# Altium schematic sheet Microcontroller.SchDoc(Microcontroller)
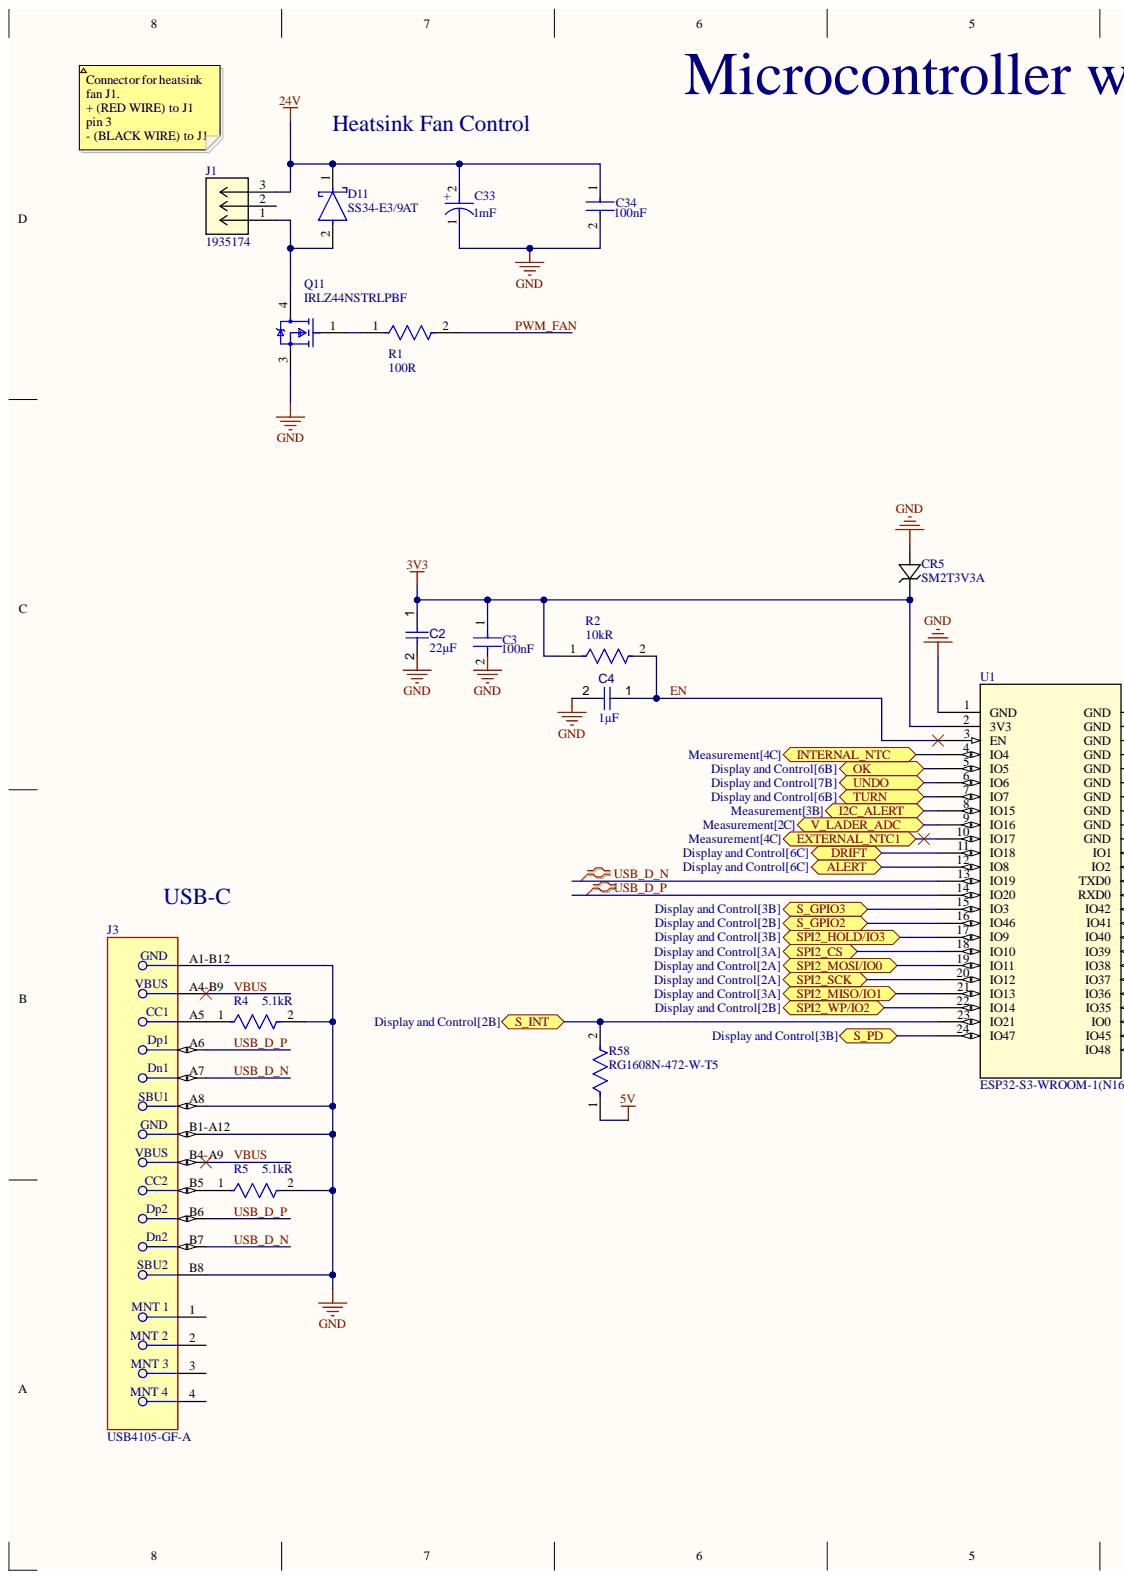
[diagram p0: Microcontroller.SchDoc, left]
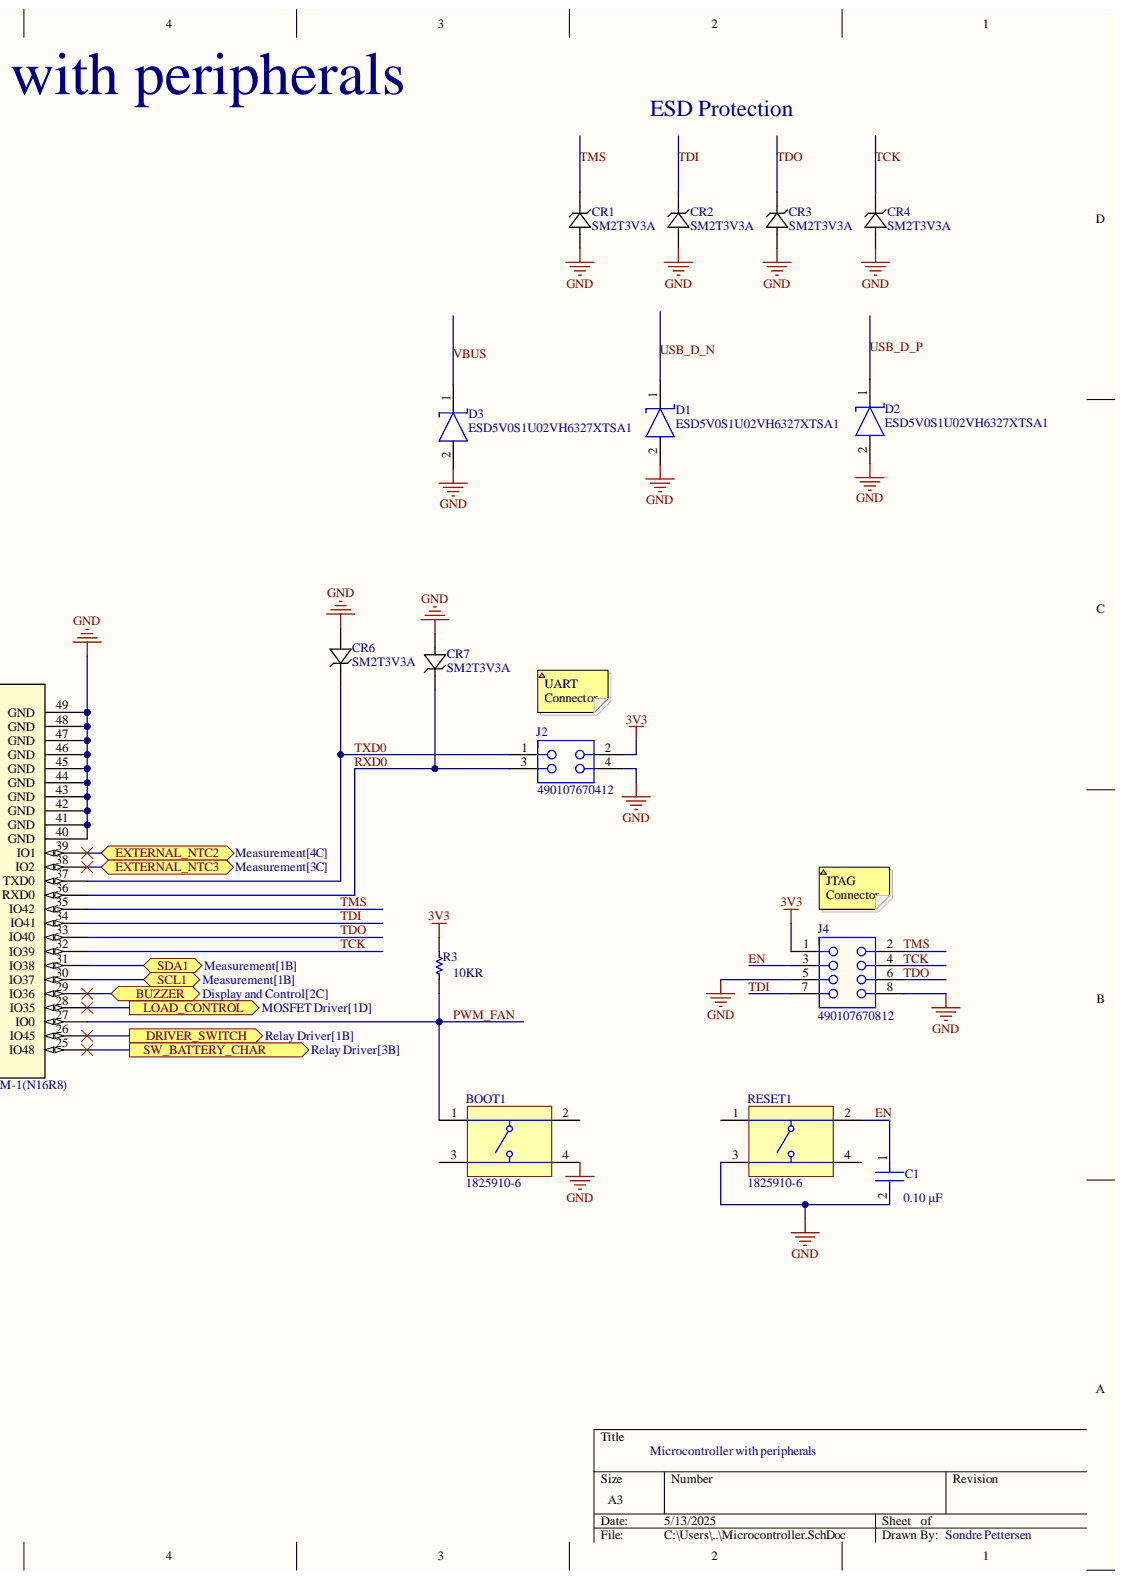
[diagram p1: Microcontroller.SchDoc, right]

## Parts
BOOT1  1825910-6  [TECO-1825910-6_V]
C1  value 0.10 μF  Capacitance: 0.10 μF  [FP-C0402-BB-MFG]  (hidden comment: C0402C104K4RACTU)
C2  value 22µF  [CAPC0805(2012)145_L]  (hidden comment: Capacitor 22µF +/-40% 16V 0805)
C3  Capacitance: 100nF  [FP-0603-L_1_6_0_15-W_0_81-IPC_C]  (hidden comment: 06035C104K4T2A)
C4  value 1µF  [CAPC1608X90X35ML15T15]  (hidden comment: Capacitor 1uF +/- 10% 16V 0603)
C33  Capacitance: 1mF  [FP-RAD-TH-D_12_5_0_5-L_25-MFG]  (hidden comment: ECA1HM102)
C34  Capacitance: 100nF  [FP-CL31-IPC_C]  (hidden comment: CL31B104KBCNFNC)
CR1  SM2T3V3A  [CR_DO-216AA_STMITE_STM]
CR2  SM2T3V3A  [CR_DO-216AA_STMITE_STM]
CR3  SM2T3V3A  [CR_DO-216AA_STMITE_STM]
CR4  SM2T3V3A  [CR_DO-216AA_STMITE_STM]
CR5  SM2T3V3A  [CR_DO-216AA_STMITE_STM]
CR6  SM2T3V3A  [CR_DO-216AA_STMITE_STM]
CR7  SM2T3V3A  [CR_DO-216AA_STMITE_STM]
D1  ESD5V0S1U02VH6327XTSA1  [FP-PG-SC79-2-MFG]
D2  ESD5V0S1U02VH6327XTSA1  [FP-PG-SC79-2-MFG]
D3  ESD5V0S1U02VH6327XTSA1  [FP-PG-SC79-2-MFG]
D11  SS34-E3/9AT  [FP-SMC-MFG]
J1  1935174  [CONN_1935174_PXC]
J2  490107670412  [490107670412_Permanent Application]
J3  USB4105-GF-A  [FP-USB4105-GF-A-MFG]
J4  490107670812  [490107670812_Permanent Application]
Q11  IRLZ44NSTRLPBF  [FP-PG-TO263-3-MFG]
R1  Resistance: 100R  [FP-ERA3A-IPC_B]  (hidden comment: ERA-3AEB101V)
R2  value 10kR  [FP-CPF0603-MFG]  (hidden comment: CPF0603B10KE1)
R3  value 10KR  [RESC2013X60X35NL10T20]  (hidden comment: RC0805FR-0710KL)
R4  Resistance: 5.1kR  [FP-CRCW0603-e3-IPC_C]  (hidden comment: CRCW06035K10FKEA)
R5  Resistance: 5.1kR  [FP-CRCW0603-e3-IPC_C]  (hidden comment: CRCW06035K10FKEA)
R58  RG1608N-472-W-T5  [FP-RG1608-MFG]
RESET1  1825910-6  [TECO-1825910-6_V]
U1  ESP32-S3-WROOM-1(N16R8)  [ESP32-S3-WROOM-1_EXP]

## Ports
ALERT, BUZZER, DRIFT, DRIVER_SWITCH, EXTERNAL_NTC1, EXTERNAL_NTC2, EXTERNAL_NTC3, I2C_ALERT, INTERNAL_NTC, LOAD_CONTROL, OK, S_GPIO2, S_GPIO3, S_INT, S_PD, SCL1, SDA1, SPI2_CS, SPI2_HOLD/IO3, SPI2_MISO/IO1, SPI2_MOSI/IO0, SPI2_SCK, SPI2_WP/IO2, SW_BATTERY_CHAR, TURN, UNDO, V_LADER_ADC

## Nets
3V3: C2.1 C3.1 CR5.1 J2.2 J4.1 R2.1 R3.2 U1.2(3V3)
5V: R58.1
24V: C33.2 C34.1 D11.1 J1.3
GND: BOOT1.4 C1.2 C2.2 C3.2 C4.2 C33.1 C34.2 CR1.2 CR2.2 CR3.2 CR4.2 CR5.2 CR6.2 CR7.2 D1.2 D2.2 D3.2 J2.4 J3.A1-B12 J3.A8 J3.B1-A12 J3.B8 J4.5 J4.8 Q11.3 R4.2 R5.2 RESET1.3 U1.1(GND) U1.40(GND) U1.41(GND) U1.42(GND) U1.43(GND) U1.44(GND) U1.45(GND) U1.46(GND) U1.47(GND) U1.48(GND) U1.49(GND)
ALERT: U1.12(IO8) ; port ALERT
BUZZER: U1.29(IO36) ; port BUZZER
DRIFT: U1.11(IO18) ; port DRIFT
DRIVER_SWITCH: U1.26(IO45) ; port DRIVER_SWITCH
EN: C1.1 C4.1 J4.3 R2.2 RESET1.2 U1.3(EN)
EXTERNAL_NTC1: U1.10(IO17) ; port EXTERNAL_NTC1
EXTERNAL_NTC2: U1.39(IO1) ; port EXTERNAL_NTC2
EXTERNAL_NTC3: U1.38(IO2) ; port EXTERNAL_NTC3
I2C_ALERT: U1.8(IO15) ; port I2C_ALERT
INTERNAL_NTC: U1.4(IO4) ; port INTERNAL_NTC
LOAD_CONTROL: U1.28(IO35) ; port LOAD_CONTROL
OK: U1.5(IO5) ; port OK
PWM_FAN: BOOT1.1 R1.2 R3.1 U1.27(IO0)
RXD0: CR7.1 J2.3 U1.36(RXD0)
S_GPIO2: U1.16(IO46) ; port S_GPIO2
S_GPIO3: U1.15(IO3) ; port S_GPIO3
S_INT: R58.2 U1.23(IO21) ; port S_INT
S_PD: U1.24(IO47) ; port S_PD
SCL1: U1.30(IO37) ; port SCL1
SDA1: U1.31(IO38) ; port SDA1
SPI2_CS: U1.18(IO10) ; port SPI2_CS
SPI2_HOLD/IO3: U1.17(IO9) ; port SPI2_HOLD/IO3
SPI2_MISO/IO1: U1.21(IO13) ; port SPI2_MISO/IO1
SPI2_MOSI/IO0: U1.19(IO11) ; port SPI2_MOSI/IO0
SPI2_SCK: U1.20(IO12) ; port SPI2_SCK
SPI2_WP/IO2: U1.22(IO14) ; port SPI2_WP/IO2
SW_BATTERY_CHAR: U1.25(IO48) ; port SW_BATTERY_CHAR
TCK: CR4.1 J4.4 U1.32(IO39)
TDI: CR2.1 J4.7 U1.34(IO41)
TDO: CR3.1 J4.6 U1.33(IO40)
TMS: CR1.1 J4.2 U1.35(IO42)
TURN: U1.7(IO7) ; port TURN
TXD0: CR6.1 J2.1 U1.37(TXD0)
UNDO: U1.6(IO6) ; port UNDO
USB_D_N: D1.1 J3.A7 J3.B7 U1.13(IO19)
USB_D_P: D2.1 J3.A6 J3.B6 U1.14(IO20)
V_LADER_ADC: U1.9(IO16) ; port V_LADER_ADC
VBUS: D3.1 J3.A4-B9 J3.B4-A9
NetD11_2: D11.2 J1.1 Q11.4
NetJ3_A5: J3.A5 R4.1
NetJ3_B5: J3.B5 R5.1
NetQ11_1: Q11.1 R1.1

Not connected: BOOT1 2 3; J1 2; J3 1 2 3 4; RESET1 1 4

## Library data of the parts
BOOT1 RESET1 = CMP-1684-00021-1: Tact Switch, SPST-NO, 0.05 A, -35 to 85 degC, 4-Pin THD, RoHS, Bulk; Manufacturer: TE Connectivity; Actuator Color: Black; Actuator Length: 1.4mm; Actuator Material: PolybutyleneTerephthalate; Actuator Style: RoundButton; Body Orientation: Straight; Bushing Type: Unthreaded; Contact Rating @ Voltage: 0.05A@24VDC; Dielectric Strength: 500VAC; Electrical Life: 100000Cycles; Function: MomentaryContact; Illumination: N/R; Maximum Contact Resistance: 100mOhm; Maximum DC Voltage Rating: 24V; Pole Throw Configuration: SPST; Switch Configuration: OFF(ON); Base Material: N/A; Component Kind: Standard; Contact Material: CopperAlloy; Contact Plating: Silver; Datasheet URL: http://www.te.com/commerce/DocumentDelivery/DDEController?Action=showdoc&DocId=Customer+Drawing%7F1825910%7FC9%7Fpdf%7FEnglish%7FENG_CD_1825910_C9.pdf%7F1825910-6; Datasheet Version: Rev. C9; Dimension: 5.99x5mm; Family: 1825910; Housing Material: N/A; Library Name: Altium Content Vault; Manufacturer URL: http://www.te.com; Maximum AC Voltage Rating: N/A; Mechanical Life: 100000Cycles; Minimum Insulation Resistance: 100MOhm; Mounting: ThroughHole; Operating Temperature: -35to85C; Package Description: 4-Pin Through Hole Device, Body 5.99 x 5.99 mm, Pitch 6.5 mm; Package Reference: THD; Packaging: N/A; Part No: 1825910-6; Product Weight: N/A; RoHS: Yes; Seal Description: N/A; Seal Location: N/A; Terminal Type: PCPins; Thread Size: N/R
C1 = CMP-2008-02108-2: CAP CER 0.1UF 16V X7R 0402; Manufacturer: Kemet; Material: Ceramic; Temperature Coefficient: 15%; Tolerance: 0.1; Automotive: No; Category: Cap; Component Kind: Standard; ComponentLink2Description: Datasheet; ComponentLink2URL: https://content.kemet.com/datasheets/KEM_C1002_X7R_SMD.pdf; Device Class L1: Passive Components; Device Class L2: Capacitors; Device Class L3: Ceramic Capacitors; Digikey Description: CAP CER 0.1UF 16V X7R 0402; Height: 0.55mm; Ipc Land Pattern Name: CAPC10050X50; Lead Free: Yes; Library Name: Altium Content Vault; Manufacturer Part Number: C0402C104K4RACTU; Mouser Description: Cap Ceramic 0.1uF 16V X7R 10% SMD 0402 125?C Paper T/R - Tape and Reel (Alt: C0402C104K4RAC TU); Octopart: https://octopart.com/c0402c104k4ractu-kemet-94158; Package: C0402-BB; RoHS: TRUE; Temperature: -55°C to +125°C; Temperature Characteristic: X7R; Temperature Range High: +125°C; Temperature Range Low: -55°C; Voltage Rating: 16 V
C2 = CMP-001-00081-4: Chip Capacitor, 22µF +/-40%, 16V, 0805, Thickness 1.45 mm; Tolerance: 5%; Case/Package: 0805; Component Kind: Standard; Max Operating Temperature: 85°C; Min Operating Temperature: -55°C; Mounting Technology: SMT; Pins: 2; Voltage Rating: 16V; Library Name: Sondre Pettersen
C3 = CMP-2006-04386-2: Automotive Ceramic Capacitor, 0603, 100nF, 10%, X7R, 15%, 50V; Dissipation Factor: 2.5%; Insulation Resistance: 100GR; Case Code (Imperial): 0603; Case Code (Metric): 1608; Case/Package: 0603; Component Kind: Standard; Construction: Flat; Contact Plating: Tin; Depth: 0.81mm; Dielectric: X7R; Dielectric Material: Ceramic; Height: 0.9mm; Lead Free: Lead Free; Length: 1.6mm; Library Name: Altium Content Vault; Max Operating Temperature: 125°C; Min Operating Temperature: -55°C; Mount: Surface Mount; Number of Pins: 2; Package Quantity: 1; Packaging: Tape and Reel; Radiation Hardening: No; Ratings: AEC-Q200; REACH SVHC: No SVHC; RoHS Compliant: Yes; Series: -; Termination: SMD/SMT; Thickness: 0.035inch; Tolerance: 10%; Voltage: 50V; Voltage Rating: 50V; Voltage Rating (DC): 50V; Width: 0.032inch
C4 = CMP-001-00004-6: Chip Capacitor, 1 uF, +/- 10%, 16 V, 0603 (1608 Metric); Capacitor Type: Ceramic; Case/Package: 0603; Component Kind: Standard; Max Operating Temperature: 125°C; Min Operating Temperature: -55°C; Mounting Technology: SM; Pins: 2; RoHS Compliant: True; Tolerance: 10%; Voltage Rating: 16V; Library Name: Sondre Pettersen
C33 = CMP-05427-001975-1: Aluminum Electrolytic Capacitors (Radial Lead Type) 1000uF ±20% 50V; Dissipation Factor: 0.12%; Lead Diameter: 0.6mm; Lead/Base Style: Straight; Life (Hours): 2000Hour; Polarity: Polar; Ripple Current: 1.05A; Case/Package: Radial; Component Kind: Standard; Construction: RADIAL; Diameter: 12.5mm; Height: 25mm; Height - Seated (Max): 1.063inch; Lead Pitch: 5mm; Length: 25mm; Library Name: Altium Content Vault; Max Operating Temperature: 85°C; Min Operating Temperature: -40°C; Mount: Through Hole; Package Quantity: 200; Packaging: Bulk; Radiation Hardening: No; REACH SVHC: No SVHC; RoHS Compliant: Yes; Series: M; Termination: Radial; Tolerance: 20%; Voltage Rating: 50V; Voltage Rating (DC): 50V
C34 = CMP-13271-001276-1: Cap Ceramic 100nF 50V X7R ±10% SMD 1206 +125°C Embossed T/R; Case Code (Imperial): 1206; Case Code (Metric): 3216; Case/Package: 1206; Component Kind: Standard; Depth: 1.6mm; Dielectric: X7R; Height: 0.85mm; Length: 0.126inch; Library Name: Altium Content Vault; Max Operating Temperature: 125°C; Min Operating Temperature: -55°C; Mount: Surface Mount; Package Quantity: 1; Packaging: Tape and Reel; Radiation Hardening: No; Thickness: 0.037inch; Tolerance: 10%; Voltage Rating: 50V; Width: 0.063inch
CR1-CR7 = SM2T3V3A: No Description Available; Mfr_Name: STMicroelectronics; Component Kind: Standard; Library Name: SM2T3V3A.IntLib; RefDes: Refdes
D1-D3 = CMP-32928-000018-1: TVS DIODE 5VWM 14.5VC SC79-2; Breakdown Voltage: 6.7V; Capacitance: 430pF; Clamping Voltage: 7.5V; Diode Type: ZENER; Direction: Unidirectional; Element Configuration: Single; ESD Protection: Yes; Max Reverse Leakage Current: 100nA; Max Surge Current: 40A; Min Breakdown Voltage: 5.7V; Number of Channels: 1; Operating Supply Voltage: 5V; Peak Pulse Current: 5.5A; Peak Pulse Power: 66W; Power Line Protection: No; Test Current: 1mA; Case/Package: SC; Component Kind: Standard; Depth: 0.8mm; Height: 0.55mm; Length: 1.2mm; Library Name: Altium Content Vault; Max Breakdown Voltage: 7.5V; Max Operating Temperature: 125°C; Min Operating Temperature: -55°C; Mount: Surface Mount; Number of Pins: 2; Number of Unidirectional Channels: 1; Package Quantity: 3000; Packaging: Tape and Reel; Polarity: Unidirectional; Radiation Hardening: No; Reach SVHC Compliant: Yes; Resistor Type: Zener; Reverse Standoff Voltage: 5V; RoHS Compliant: Yes; Series: -; Termination: SMD/SMT; Width: 0.8mm; Working Voltage: 5V
D11 = CMP-02539-000066-1: DIODE SCHOTTKY 40V 3A DO214AB; Element Configuration: Single; Forward Voltage: 500mV; Max Reverse Current: 500uA; Max Surge Current: 100A; Average Rectified Current: 3A; Case/Package: SMC; Component Kind: Standard; Forward Current: 3A; Library Name: Altium Content Vault; Max Operating Temperature: 125°C; Max Repetitive Reverse Voltage (Vrrm): 40V; Max Reverse Leakage Current: 500uA; Max Reverse Voltage (DC): 40V; Min Operating Temperature: -55°C; Mount: Surface Mount; Number of Pins: 2; Package Quantity: 3500; Packaging: Tape and Reel; Peak Non-Repetitive Surge Current: 100A; Radiation Hardening: No; RoHS Compliant: Yes; Series: -
J1 = 1935174: No Description Available; Mfr_Name: Phoenix Contact; Component Kind: Standard; Library Name: 1935174.IntLib; RefDes: RefDes; Type: Type
J2 = CMP-1502-02448-2: NexarPartId: 87855881; Contact Resistance: 10mΩ; Current Rating: 1A; Gender: Receptacle; Operating Supply Voltage: 500V; Component Kind: Standard; Lead Pitch: 2.54mm; Library Name: Altium Content Vault; Number of Pins: 4; RoHS: Non-Compliant
J3 = CMP-245163-000001-1: CONN RCPT USB2.0 TYPEC 5A; Manufacturer: GCT; Conn Orientation: Right Angle; Current Rating: 5/6.25/1.25/0.25A; Is Female: yes; Number Of Contacts: 16; Voltage Rating: 20V; Automotive: No; Category: Conn; Component Kind: Standard; ComponentLink2Description: Datasheet; ComponentLink2URL: https://gct.co/files/drawings/usb4105.pdf; Contact Material: Gold,Nickel; Device Class L1: Connectors; Device Class L2: USB Connectors; Device Class L3: unset; Digikey Description: CONN RCPT USB2.0 TYPEC 5A; Height: 3.46mm; Lead Free: Yes; Library Name: Altium Content Vault; Manufacturer Part Number: USB4105-GF-A; Octopart: https://octopart.com/usb4105-gf-a-gct-106627878; Package: 0; RoHS: TRUE; Temperature: -40°C to +85°C; Temperature Range High: +85°C; Temperature Range Low: -40°C; Usb Standard: USB 2.0
J4 = CMP-1502-02450-2: NexarPartId: 87855883; Contact Resistance: 10mΩ; Current Rating: 1A; Gender: Receptacle; Operating Supply Voltage: 500V; Component Kind: Standard; Lead Pitch: 2.54mm; Library Name: Altium Content Vault; Number of Pins: 8; RoHS: Non-Compliant
Q11 = CMP-32901-000495-1: MOSFET N-CH 55V 47A D2PAK; Drain to Source Resistance: 35mR; Element Configuration: Single; Gate to Source Voltage (Vgs): 16V; Input Capacitance: 1.7nF; Max Power Dissipation: 3.8W; Power Dissipation: 110W; Rds On Max: 22mR; Threshold Voltage: 2V; Turn-Off Delay Time: 26ns; Turn-On Delay Time: 11ns; Case/Package: D2PAK; Component Kind: Standard; Continuous Drain Current (ID): 47A; Drain to Source Breakdown Voltage: 55V; Drain to Source Voltage (Vdss): 55V; Halogen Free: Halogen Free; Height: 0.19inch; Lead Free: Contains Lead; Length: 0.42inch; Library Name: Altium Content Vault; Max Dual Supply Voltage: 55V; Max Operating Temperature: 175°C; Min Operating Temperature: -55°C; Mount: Surface Mount; Number of Pins: 3; Packaging: Tape and Reel; REACH SVHC: No SVHC; RoHS Compliant: Yes; Width: 0.38inch
R1 = CMP-2000-00870-2: RES SMD 100 OHM 0.1% 1/10W 0603; Max Power Dissipation: 100mW; Temperature Coefficient: 25ppm/°C; Voltage Rating: 75V; Case Code (Imperial): 0603; Case Code (Metric): 1608; Case/Package: 0603; Component Kind: Standard; Construction: Rectangular; Contact Plating: Tin; Depth: 0.8mm; Height: 0.45mm; Length: 1.6mm; Library Name: Altium Content Vault; Max Operating Temperature: 155°C; Military Standard: Not; Min Operating Temperature: -55°C; Mount: Surface Mount; Number of Pins: 2; Number of Terminations: 2; Package Quantity: 5000; Packaging: Tape and Reel; Power Rating: 100mW; Radiation Hardening: No; REACH SVHC: No SVHC; Resistance Tolerance: 0.1%; Resistor Type: Thin Film; RoHS Compliant: Yes; Series: ERA-3A; Size Code: 0603; Technology: METAL FILM; Termination: SMD/SMT; Tolerance: 0.1%; Width: 0.8mm
R2 = CMP-03211-003455-1: RES SMD 10KΩ 0.1% 1/16W 0603; Manufacturer: TE Connectivity; Power Rating: 0.063W; Temperature Coefficient: 25ppm/°C; Voltage Rating: 50V; Automotive: No; Category: Res; Component Kind: Standard; ComponentLink2Description: Datasheet; ComponentLink2URL: https://www.te.com/commerce/DocumentDelivery/DDEController?Action=showdoc&DocId=Data+Sheet%7F1773200%7FM%7Fpdf%7FEnglish%7FENG_DS_1773200_M.pdf%7F3-1676481-2; Composition: Thin Film; Device Class L1: Passive Components; Device Class L2: Resistors; Device Class L3: Chip SMD Resistors; Height: 0.55mm; Ipc Land Pattern Name: RESC15580X45; Lead Free: Yes; Library Name: Altium Content Vault; Manufacturer Part Number: CPF0603B10KE1; Octopart: https://octopart.com/cpf0603b10ke1-te+connectivity-9539431; octopart_url: https://octopart.com/cpf0603b10ke1-te+connectivity-9539431; Package: CPF0603; packaging: 1k Reel; Resistance: 10KΩ; RoHS: TRUE; Series: CPF; Temperature: -55°C to +155°C; Temperature Range High: +155°C; Temperature Range Low: -55°C; Tolerance: 0.1%
R3 = CMP-1659-00013-4: Chip Resistor, 10 KOhm, +/- 1%, 0.125 W, -55 to 155 degC, 0805 (2012 Metric), RoHS, Tape and Reel; Manufacturer: Yageo; Voltage Rating: 150 V; Component Kind: Standard; ComponentLink1Description: Manufacturer URL; ComponentLink1URL: http://www.yageo.com/; ComponentLink2Description: Datasheet; ComponentLink2URL: http://www.yageo.com/documents/recent/PYu-RC_Group_51_RoHS_L_04.pdf; DatasheetVersion: Rev. 4, 02/2016; Height: 0.5 mm; Length: 2 mm; Library Name: Altium Content Vault; Max Operating Temperature: 155 degC; Min Operating Temperature: -55 degC; Mount: Surface Mount; Mounting Technology: Surface Mount; Package Quantity: 5000; PackageDescription: 2-Pin Surface Mounted Device, Body 2 x 1.25 mm; PackageReference: 0805; Packaging: Tape and Reel; Pins: 2; REACH SVHC: No SVHC; RoHSCompliant: true
R4 R5 = CMP-1012-00590-3: RES Thick Film, 5.1kΩ, 1%, 0.1W, 100ppm/°C, 0603; Power Rating: 100mW; Voltage Rating: 75V; Case Code (Imperial): 0603; Case Code (Metric): 1608; Case/Package: 0603; Component Kind: Standard; Composition: Thick Film; Contact Plating: Tin; Depth: 0.85mm; Height: 0.45mm; Lead Free: Lead Free; Length: 1.55mm; Library Name: Altium Content Vault; Max Operating Temperature: 155°C; Military Standard: Not; Min Operating Temperature: -55°C; Mount: Surface Mount; Package Quantity: 5000; Packaging: Tape and Reel; Radiation Hardening: No; Temperature Coefficient: 100ppm/°C; Termination: SMD/SMT; Tolerance: 1%; Voltage Rating (DC): 75V; Weight: 7.1E-05oz; Width: 0.85mm
R58 = CMP-28537-009249-1: Automotive Metal Thin Film Chip Resistor, 0603, 4.7kΩ, 0.05%, 10ppm/°C, 0.1W, 100V; Max Power Dissipation: 100mW; Resistance: 4.7kR; Voltage Rating (DC): 75V; Case Code (Imperial): 0603; Case Code (Metric): 1608; Case/Package: 0603; Component Kind: Standard; Features: Automotive AEC-Q200; Height: 0.02inch; Lead Free: Lead Free; Length: 0.063inch; Library Name: Altium Content Vault; Max Operating Temperature: 155°C; Min Operating Temperature: -55°C; Number of Terminations: 2; Package Quantity: 5000; Packaging: Tape and Reel; Power Rating: 100mW; Resistor Type: Thin Film; RoHS Compliant: Yes; Series: RG; Size Code: 0603; Temperature Coefficient: 10ppm/°C; Termination: SMD/SMT; Tolerance: 0.05%; Voltage Rating: 100V; Weight: 7.1E-05oz; Width: 0.8mm
U1 = CMP-020-00024-3: SMD mod, ESP32-S3R8 with 3.3V, 8MB Octal PSRAM die insid, 6MBQuad SPI flash, pcb ant; Clock Frequency: 240MHz; I/Os: 34; Max Supply Voltage: 3.6V; Min Supply Voltage: 3V; Power: 1.65W; RAM Size: 512kB; Component Kind: Standard; Max Operating Temperature: 65°C; Min Operating Temperature: -40°C; Mounting Technology: SMT; Nominal Supply Voltage: 3.3V; Pins: 41; Library Name: Sondre Pettersen
